# Altium schematic sheet STM32_Peripheral.SchDoc(STM32_Peripheral)
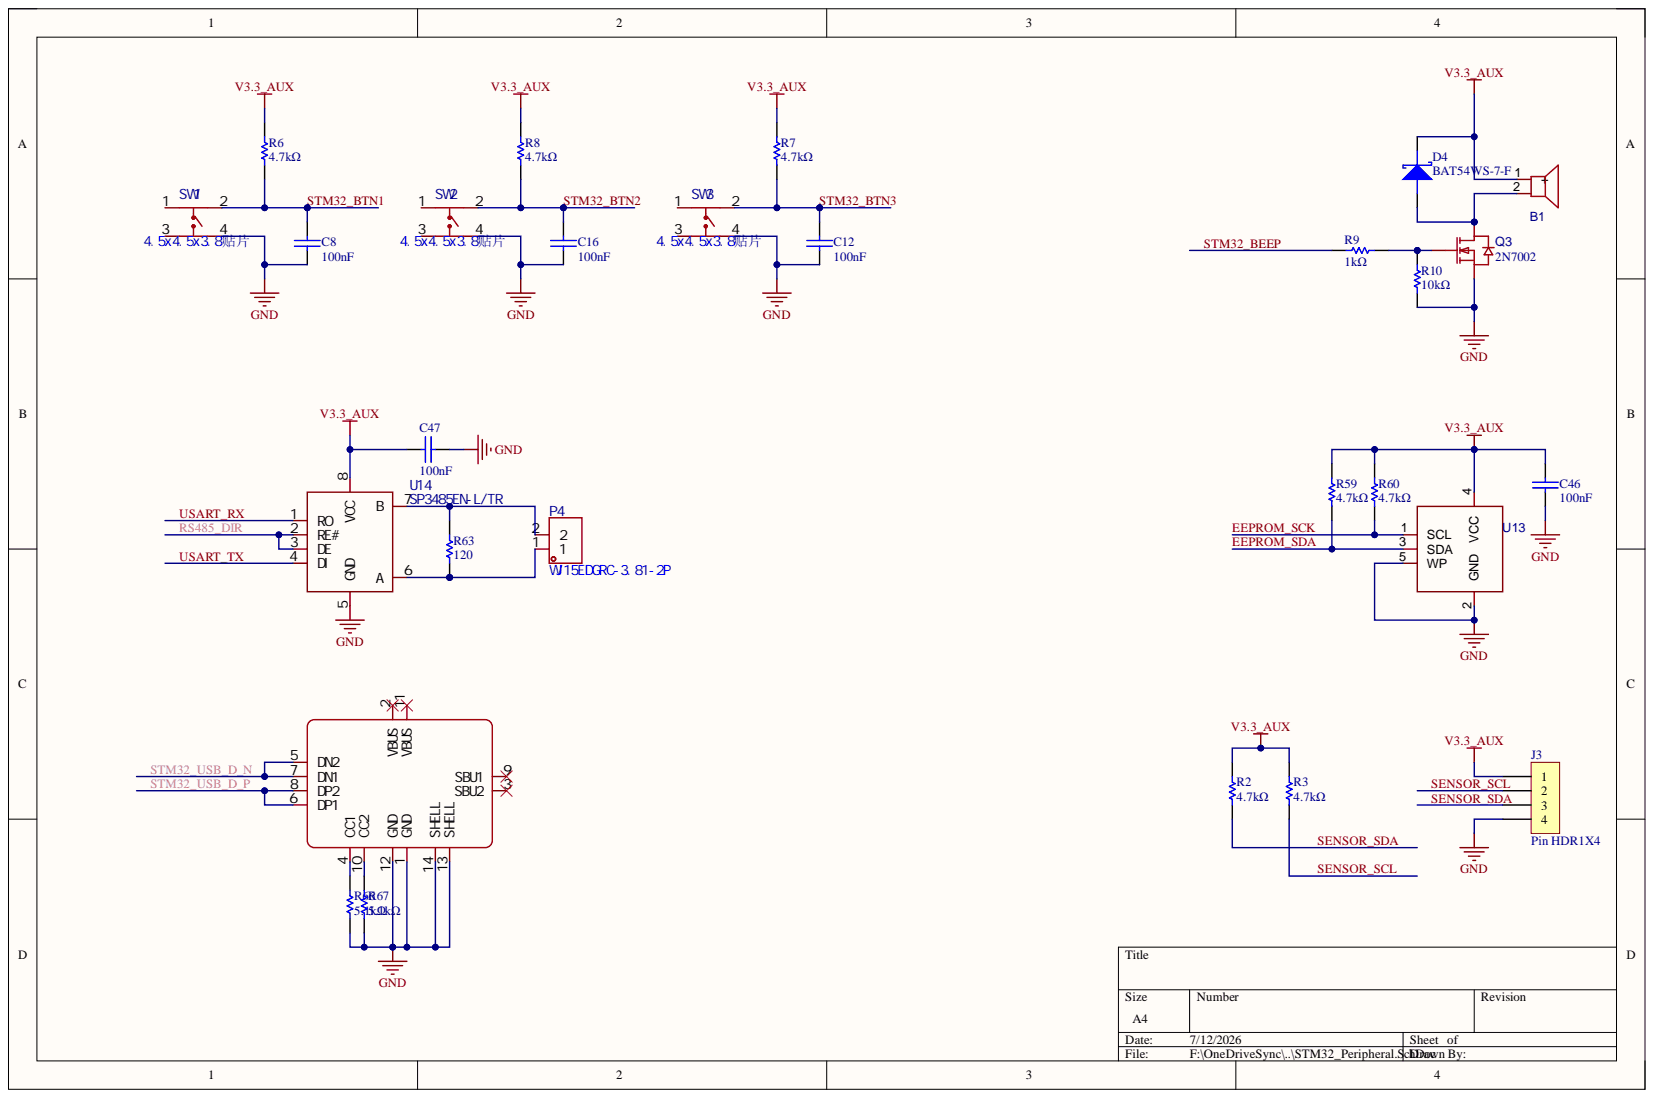
[diagram p0: STM32_Peripheral.SchDoc]

## Parts
B1  HNB09A05  [BUZ-TH_BD9.6-P5.00-D0.7-FD]  (value not drawn)
C8  100nF  [0402C]
C12  100nF  [0402C]
C16  100nF  [0402C]
C46  100nF  [0402C]
C47  100nF  [0402C]
D4  BAT54WS-7-F  [SOD-323]
J3  Pin HDR1X4  [Pin HDR1X4/2.54mm-S]
P4  WJ15EDGRC-3.81-2P  [CONN-TH_2P-P3.81_WJ15EDGRC-3.81-2P]
Q3  2N7002  [SOT-23-3_L2.9-W1.3-P1.90-LS2.4-BR]
R2  4.7kΩ  [0402R]
R3  4.7kΩ  [0402R]
R6  4.7kΩ  [0402R]
R7  4.7kΩ  [0402R]
R8  4.7kΩ  [0402R]
R9  1kΩ  [0402R]
R10  10kΩ  [0402R]
R59  4.7kΩ  [0402R]
R60  4.7kΩ  [0402R]
R63  120  [0402R]
R66  5.1kΩ  [0402R]
R67  5.1kΩ  [0402R]
SW1  4.5x4.5x3.8贴片  [SW-SMD_4P-L4.5-W4.5-P3.00-LS6.8]
SW2  4.5x4.5x3.8贴片  [SW-SMD_4P-L4.5-W4.5-P3.00-LS6.8]
SW3  4.5x4.5x3.8贴片  [SW-SMD_4P-L4.5-W4.5-P3.00-LS6.8]
U13  AT24C08D-STUM-T-TUDI  [SOT-23-5_L3.0-W1.7-P0.95-LS2.8-BR]  (value not drawn)
U14  SP3485EN-L/TR  [SOIC-8_L5.0-W4.0-P1.27-LS6.0-BL]
USB1  TYPE-C 16PIN 2MD(073)  [USB-C-SMD_TYPE-C-6PIN-2MD-073]  (value not drawn)

## Nets
GND: C8.1 C12.1 C16.1 C46.1 C47.1 J3.4 Q3.2 R10.1 R66.2 R67.2 SW1.4 SW2.4 SW3.4 U13.2(GND) U13.5(WP) U14.5(GND) USB1.1(GND) USB1.12(GND) USB1.13(SHELL) USB1.14(SHELL)
V3.3_AUX: B1.1 C46.2 C47.2 D4.K J3.1 R2.1 R3.1 R6.2 R7.2 R8.2 R59.1 R60.1 U13.4(VCC) U14.8(VCC)
EEPROM_SCK: R60.2 U13.1(SCL)
EEPROM_SDA: R59.2 U13.3(SDA)
RS485_DIR: U14.2(RE#) U14.3(DE)
SENSOR_SCL: J3.2 R3.2
SENSOR_SDA: J3.3 R2.2
STM32_BEEP: R9.1
STM32_BTN1: C8.2 R6.1 SW1.2
STM32_BTN2: C16.2 R8.1 SW2.2
STM32_BTN3: C12.2 R7.1 SW3.2
STM32_USB_D_N: USB1.5(DN2) USB1.7(DN1)
STM32_USB_D_P: USB1.6(DP1) USB1.8(DP2)
USART_RX: U14.1(RO)
USART_TX: U14.4(DI)
NetB1_2: B1.2 D4.A Q3.3
NetP4_1: P4.1 R63.1 U14.6(A)
NetP4_2: P4.2 R63.2 U14.7(B)
NetQ3_1: Q3.1 R9.2 R10.2
NetR66_1: R66.1 USB1.4(CC1)
NetR67_1: R67.1 USB1.10(CC2)

Not connected: SW1 1 3; SW2 1 3; SW3 1 3; USB1 2(VBUS) 3(SBU2) 9(SBU1) 11(VBUS)

## Library data of the parts
B1 = HNB09A05: Buzzer; Component Kind: Standard; Symbol: HNB09A05; Library Name: GMP_PB_Core_Lib.SchLib
C8 C12 C16 C46 C47 = CAP: 无极性电容; Component Kind: Standard; Library Name: 电容.IntLib
D4 = DIODE SCHOTTKY: 肖特基二极管; Component Kind: Standard; Library Name: 二极管.IntLib
P4 = WJ15EDGRC-3.81-2P: C8387; Manufacturer: KANGNEX(康奈克斯电气); Add into BOM: yes; Connector Type: 板端/插座-闭口; Current Rating: 8A; Number of PINs Per Row: 2; Number of Positions or Pins: 1x2P; Pitch: 3.81mm; Voltage Rating (Max): 300V; Color: -; Component Kind: Standard; Connection Type: -; Convert to PCB: yes; Datasheet: https://item.szlcsc.com/datasheet/WJ15EDGRC-3.81-2P/8881.html; Device: WJ15EDGRC-3.81-2P; JLCPCB Part Class: 扩展库; LCSC Part Name: 1x2P 3.81mm 排数:1 每排P数:2; Manufacturer Part: WJ15EDGRC-3.81-2P; Mounting Type: 弯针; Number of Pins: 2P; Number of Rows: 1; Operating Temperature: -40℃~+105℃; Outlet Direction (Vertical Basis): -; PCB3D: CONN-TH_2P_L8.5-W9.2-H7.0-P3.81; Supplier: LCSC; Supplier Footprint: 弯插,P=3.81mm; Supplier Part: C8387; Symbol: WJ15EDGRC-3.81-2P; Termination Style: -; Wire Gauge - AWG: -; Wire Gauge - mm2: -
Q3 = 2N7002: MOSFET; Symbol: 2N7002_C7420321; Component Kind: Standard; Library Name: GMP_PB_Core_Lib.SchLib
R2 R3 R6-R10 R59 R60 R63 R66 R67 = RES: Component Kind: Standard; Library Name: 电阻.IntLib
SW1-SW3 = 4.5x4.5x3.8贴片: Manufacturer: BOOMELE(博穆精密); Actuator Style: 圆形按钮; Actuator/Cap Color: 黑色; Add into BOM: yes; Circuit: 单刀单掷; Insulation Resistance: 100MΩ; Mechanical Life: 10万次; Operating Force: 260gf; Pin Style: SMD接片; Strike Gundam: 不带; Switch Height: 3.8mm; Switch Length: 4.5mm; Voltage Rating (DC): 12V; Component Kind: Standard; Convert to PCB: yes; Datasheet: https://atta.szlcsc.com/upload/public/pdf/source/20200916/C174049_C7EFE601E72DB861B2B81D6E1A27ADFA.pdf; Device: 4.5x4.5x3.8贴片; JLCPCB Part Class: 扩展库; LCSC Part Name: 4.5*4.5*3.8mm 立贴 轻触开关; Manufacturer Part: 4.5x4.5x3.8贴片; Mounting Style: 立贴; Operating Temperature: -20℃~+80℃; PCB3D: SW-SMD_4P-L4.5-W4.5-H6.5-P3.00-LS6.8; Supplier: LCSC; Supplier Footprint: SMD; Supplier Part: C2897501; Switch Width: 4.5mm; Symbol: 4.5X4.5X3.8贴片
U13 = AT24C08D-STUM-T-TUDI: Peripheral EEPROM 8kB; Component Kind: Standard; Symbol: AT24C08D-STUM-T-TUDI; Library Name: GMP_PB_Core_Lib.SchLib
U14 = SP3485EN-L/TR: Manufacturer: MaxLinear(迈凌); Add into BOM: yes; Data Rate: 10Mbps; Number of Driver: 1; Supply Voltage: 3.3V; Types: 收发器; Component Kind: Standard; Convert to PCB: yes; Datasheet: https://atta.szlcsc.com/upload/public/pdf/source/20170601/1496285796963.pdf; Device: SP3485EN-L/TR; JLCPCB Part Class: 基础库; LCSC Part Name: SP3485 +3.3V低功耗半双工RS-485收发器，数据速率10Mbps; Manufacturer Part: SP3485EN-L/TR; Number of receiver: 1; Operating Temperature: -40℃~+85℃; PCB3D: SOIC-8_L4.9-W3.9-H1.7-LS6.0-P1.27; Supplier: LCSC; Supplier Footprint: SOIC-8; Supplier Part: C8963; Symbol: SP3485EN-L/TR; Library Name: GMP_PB_Core_Lib.SchLib
USB1 = TYPE-C 16PIN 2MD(073): USB Type C 12P; Manufacturer: SHOU HAN(首韩); Add into BOM: yes; Connect-Disconnect Life: 5千次; Current Rating-Power: 3A; Detection color: 黑色; Gender: 母; Number of Contacts: 16P; Number of Ports: 1; Voltage Rating: 5V; Center Height: -; Component Kind: Standard; Connector Type: Type-C; Convert to PCB: yes; Datasheet: https://item.szlcsc.com/datasheet/TYPE-C%252016PIN%25202MD(073)/2901843.html; Device: TYPE-C 16PIN 2MD(073); JLCPCB Part Class: Extended Part; LCSC Part Name: Type-C 母 卧贴; Length: -; Manufacturer Part: TYPE-C 16PIN 2MD(073); Mounting Type: 卧贴; Operating Temperature: -25℃~+85℃; PCB3D: USB-C-SMD_TYPE-C-6PIN-2MD-073; Protocol Standard: -; Supplementary Features: -; Supplier: LCSC; Supplier Footprint: SMD; Supplier Part: C2765186; Symbol: TYPE-C 16PIN 2MD(073); Library Name: GMP_PB_Core_Lib.SchLib
J3 = Pin HDR1X4: Component Kind: Standard; Library Name: 排针.IntLib
